# Altium schematic sheet Logic.SchDoc(Logic)
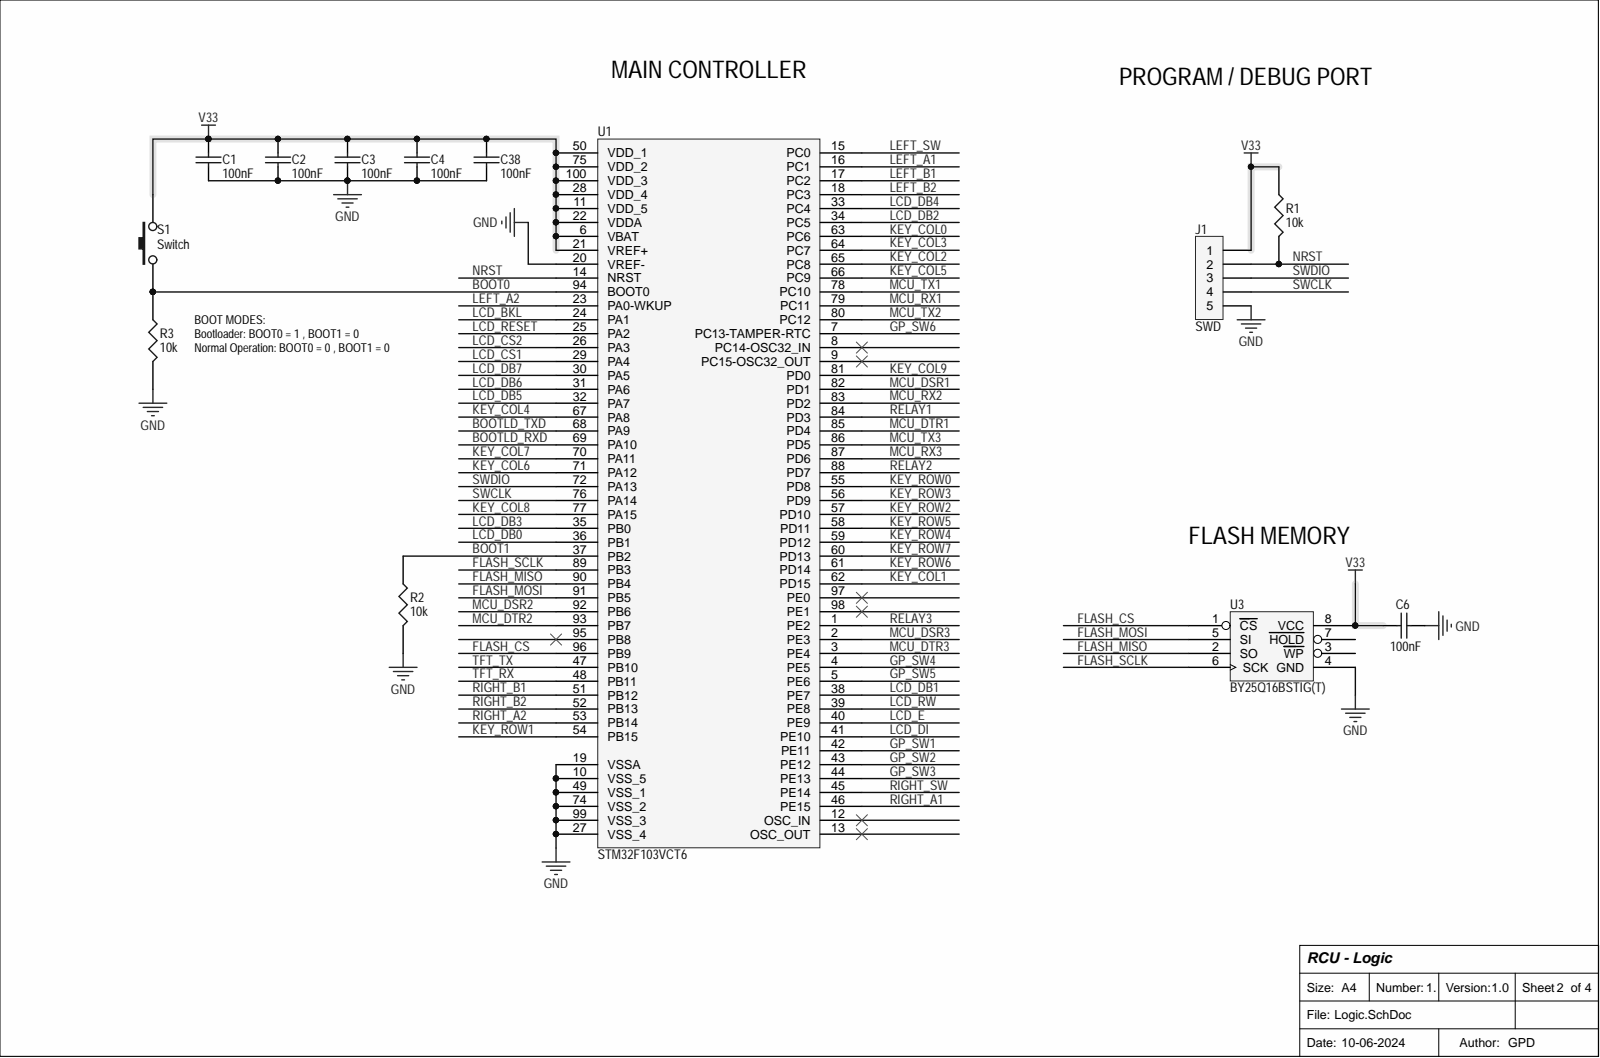
[diagram p0: Logic.SchDoc]

## Parts
C1  100nF  [C0402]
C2  100nF  [C0402]
C3  100nF  [C0402]
C4  100nF  [C0402]
C6  100nF  [C0402]
C38  100nF  [C0402]
J1  SWD  [HDR1X5]
R1  10k  [R0402]
R2  10k  [R0402]
R3  10k  [R0402]
S1  Switch  [SWITCH_SMD_1]
U1  STM32F103VCT6  [F-QFP14X14-G100/N]
U3  BY25Q16BSTIG(T)  [SO-G8]

## Nets
GND: C1.2 C2.2 C3.2 C4.2 C6.1 C38.2 J1.5 R2.2 R3.2 U1.10(VSS_5) U1.19(VSSA) U1.20(VREF-) U1.27(VSS_4) U1.49(VSS_1) U1.74(VSS_2) U1.99(VSS_3) U3.4(GND)
V33: C1.1 C2.1 C3.1 C4.1 C6.2 C38.1 J1.1 R1.1 S1.1 U1.6(VBAT) U1.11(VDD_5) U1.21(VREF+) U1.22(VDDA) U1.28(VDD_4) U1.50(VDD_1) U1.75(VDD_2) U1.100(VDD_3) U3.8(VCC)
BOOT0: R3.1 S1.2 U1.94(BOOT0)
BOOT1: R2.1 U1.37(PB2)
BOOTLD_RXD: U1.69(PA10)
BOOTLD_TXD: U1.68(PA9)
FLASH_CS: U1.96(PB9) U3.1(CS)
FLASH_MISO: U1.90(PB4) U3.2(SO)
FLASH_MOSI: U1.91(PB5) U3.5(SI)
FLASH_SCLK: U1.89(PB3) U3.6(SCK)
GP_SW1: U1.42(PE11)
GP_SW2: U1.43(PE12)
GP_SW3: U1.44(PE13)
GP_SW4: U1.4(PE5)
GP_SW5: U1.5(PE6)
GP_SW6: U1.7(PC13-TAMPER-RTC)
KEY_COL0: U1.63(PC6)
KEY_COL1: U1.62(PD15)
KEY_COL2: U1.65(PC8)
KEY_COL3: U1.64(PC7)
KEY_COL4: U1.67(PA8)
KEY_COL5: U1.66(PC9)
KEY_COL6: U1.71(PA12)
KEY_COL7: U1.70(PA11)
KEY_COL8: U1.77(PA15)
KEY_COL9: U1.81(PD0)
KEY_ROW0: U1.55(PD8)
KEY_ROW1: U1.54(PB15)
KEY_ROW2: U1.57(PD10)
KEY_ROW3: U1.56(PD9)
KEY_ROW4: U1.59(PD12)
KEY_ROW5: U1.58(PD11)
KEY_ROW6: U1.61(PD14)
KEY_ROW7: U1.60(PD13)
LCD_BKL: U1.24(PA1)
LCD_CS1: U1.29(PA4)
LCD_CS2: U1.26(PA3)
LCD_DB0: U1.36(PB1)
LCD_DB1: U1.38(PE7)
LCD_DB2: U1.34(PC5)
LCD_DB3: U1.35(PB0)
LCD_DB4: U1.33(PC4)
LCD_DB5: U1.32(PA7)
LCD_DB6: U1.31(PA6)
LCD_DB7: U1.30(PA5)
LCD_DI: U1.41(PE10)
LCD_E: U1.40(PE9)
LCD_RESET: U1.25(PA2)
LCD_RW: U1.39(PE8)
LEFT_A1: U1.16(PC1)
LEFT_A2: U1.23(PA0-WKUP)
LEFT_B1: U1.17(PC2)
LEFT_B2: U1.18(PC3)
LEFT_SW: U1.15(PC0)
MCU_DSR1: U1.82(PD1)
MCU_DSR2: U1.92(PB6)
MCU_DSR3: U1.2(PE3)
MCU_DTR1: U1.85(PD4)
MCU_DTR2: U1.93(PB7)
MCU_DTR3: U1.3(PE4)
MCU_RX1: U1.79(PC11)
MCU_RX2: U1.83(PD2)
MCU_RX3: U1.87(PD6)
MCU_TX1: U1.78(PC10)
MCU_TX2: U1.80(PC12)
MCU_TX3: U1.86(PD5)
NRST: J1.2 R1.2 U1.14(NRST)
RELAY1: U1.84(PD3)
RELAY2: U1.88(PD7)
RELAY3: U1.1(PE2)
RIGHT_A1: U1.46(PE15)
RIGHT_A2: U1.53(PB14)
RIGHT_B1: U1.51(PB12)
RIGHT_B2: U1.52(PB13)
RIGHT_SW: U1.45(PE14)
SWCLK: J1.4 U1.76(PA14)
SWDIO: J1.3 U1.72(PA13)
TFT_RX: U1.48(PB11)
TFT_TX: U1.47(PB10)

Not connected: U1 8(PC14-OSC32_IN) 9(PC15-OSC32_OUT) 12(OSC_IN) 13(OSC_OUT) 95(PB8) 97(PE0) 98(PE1); U3 3(WP) 7(HOLD)

## Library data of the parts
C1-C4 C6 C38 = CAP: 100nF ±10% 16V X7R 0402 Multilayer Ceramic Capacitors MLCC - SMD/SMT RoHS; Manufacturer Part Number: C0402C104K4RAC-TU; Manufacturer: KEMET; Value: 100nF; Supplier Part Number 3: 399-C0402C104K4RAC7867DKR-ND; Supplier Part Number 2: 8015145; Supplier Part Number 1: 80-C0402C104K4R; Supplier 3: Digikey; Supplier 2: Rs; Supplier 1: Mouser; Library Name: Miscellaneous Devices.SchLib; Component Kind: Standard
J1 = CON5: Free pads - do not populate; Value: SWD; Supplier Part Number 3: N/A; Supplier Part Number 2: N/A; Supplier Part Number 1: N/A; Supplier 3: N/A; Supplier 2: N/A; Supplier 1: N/A; Manufacturer Part Number: N/A; Manufacturer: N/A; Library Name: Miscellaneous Devices.SchLib; Component Kind: Standard
R1-R3 = RES1: SMD Chip Resistor, 10 kOhm, ± 5%, 63 mW, 0402 [1005 Metric], Thick Film, General Purpose; Manufacturer Part Number: CRCW040210K0JNED; Manufacturer: Vishay Dale; Value: 10k; Supplier Part Number 3: 541-10KJDKR-ND; Supplier Part Number 2: C191984; Supplier Part Number 1: 71-CRCW0402J-10K-E3; Supplier 3: Digikey; Supplier 2: LCSC; Supplier 1: Mouser; Library Name: Miscellaneous Devices.SchLib; Component Kind: Standard
S1 = SW-PB: N/O 0.6W 50mA 6mm 100MΩ 250gf 12V 6mm 5mm Round Button Brick nogging SPST SMD,6x6mm Tactile Switches ROHS; Manufacturer Part Number: FSM2JSMAA; Manufacturer: TE Connectivity; Value: Switch; Supplier Part Number 3: 506-2-1437565-8; Supplier Part Number 2: 2985663; Supplier Part Number 1: C255812; Supplier 3: Mouser; Supplier 2: Farnell; Supplier 1: LCSC; Library Name: Miscellaneous Devices.SchLib; Component Kind: Standard
U1 = STM32F103VCT6: MCU 32-bit STM32F1 ARM Cortex M3 RISC 256KB Flash 2.5V/3.3V 100-Pin LQFP Tray; Manufacturer: STMicroelectronics; Value: STM32F103VCT6; Supplier Part Number 3: 1624139; Supplier Part Number 2: 511-STM32F103VCT6; Supplier Part Number 1: C8313; Supplier 3: Farnell; Supplier 2: Mouser; Supplier 1: LCSC; Manufacturer Part Number: STM32F103VCT6; Library Name: STM32.SchLib; ComponentLink1URL: https://datasheet.ciiva.com/8054/1831497-8054965.pdf; ComponentLink1Description: Datasheet; Component Kind: Standard
U3 = AT25F2048: SOP-8 NOR FLASH ROHS; Manufacturer: BOYAMICRO; Value: BY25Q16BSTIG(T); Supplier Part Number 3: C382744; Supplier Part Number 2: C382744; Supplier Part Number 1: C382744; Supplier 3: LCSC; Supplier 2: LCSC; Supplier 1: LCSC; Manufacturer Part Number: BY25Q16BSTIG(T); Library Name: ICs.SchLib; ComponentLink1URL: https://datasheet.lcsc.com/lcsc/1912111437_BOYAMICRO-BY25Q16BSTIG-T_C382744.pdf?_gl=1*72e4c3*_gcl_au*MTUzNDU1MTE0Mi4xNzE0NDQxNzc1*_ga*NDgwNzM5Njg2LjE3MTQ0NDE3Nzc.*_ga_98M84MKSZH*MTcxNTI5MzI1My4xNS4xLjE3MTUyOTMyNTQuNTkuMC4w; ComponentLink1Description: Datasheet; Component Kind: Standard
